# Altium schematic sheet CurrentSensorR3.SchDoc
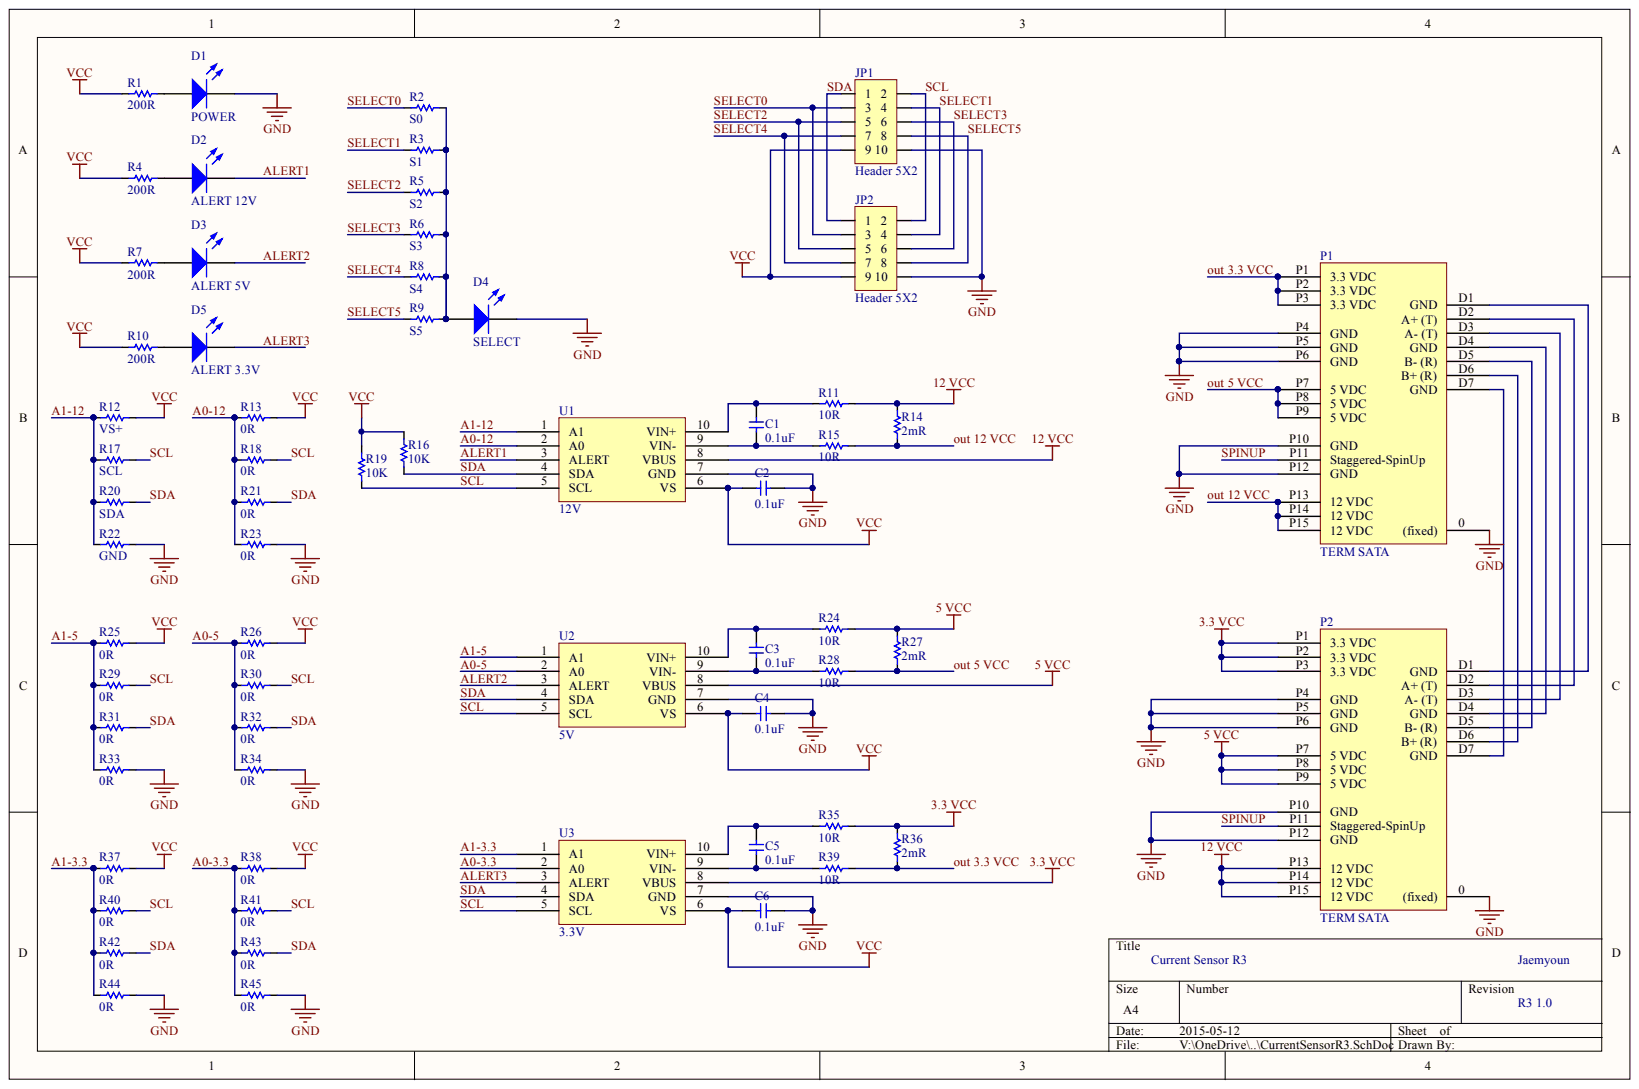
[diagram p0: CurrentSensorR3.SchDoc]

## Parts
C1  0.1uF  [C1608]
C2  0.1uF  [C1608]
C3  0.1uF  [C1608]
C4  0.1uF  [C1608]
C5  0.1uF  [C1608]
C6  0.1uF  [C1608]
D1  POWER  [LED-0603]
D2  ALERT 12V  [LED-0603]
D3  ALERT 5V  [LED-0603]
D4  SELECT  [LED-0603]
D5  ALERT 3.3V  [LED-0603]
JP1  Header 5X2  [TPH03-5A]
JP2  Header 5X2  [TPH03-5A]
P1  TERM SATA  [47018-4001]
P2  TERM SATA  [87703-0001]
R1  200R  [R1608]
R2  S0  [R1608]
R3  S1  [R1608]
R4  200R  [R1608]
R5  S2  [R1608]
R6  S3  [R1608]
R7  200R  [R1608]
R8  S4  [R1608]
R9  S5  [R1608]
R10  200R  [R1608]
R11  10R  [R1608]
R12  VS+  [R1608]
R13  0R  [R1608]
R14  2mR  [R-Shunt]
R15  10R  [R1608]
R16  10K  [R1608]
R17  SCL  [R1608]
R18  0R  [R1608]
R19  10K  [R1608]
R20  SDA  [R1608]
R21  0R  [R1608]
R22  GND  [R1608]
R23  0R  [R1608]
R24  10R  [R1608]
R25  0R  [R1608]
R26  0R  [R1608]
R27  2mR  [R-Shunt]
R28  10R  [R1608]
R29  0R  [R1608]
R30  0R  [R1608]
R31  0R  [R1608]
R32  0R  [R1608]
R33  0R  [R1608]
R34  0R  [R1608]
R35  10R  [R1608]
R36  2mR  [R-Shunt]
R37  0R  [R1608]
R38  0R  [R1608]
R39  10R  [R1608]
R40  0R  [R1608]
R41  0R  [R1608]
R42  0R  [R1608]
R43  0R  [R1608]
R44  0R  [R1608]
R45  0R  [R1608]
U1  12V  [DGS10-M]
U2  5V  [DGS10-M]
U3  3.3V  [DGS10-M]

## Nets
3.3 VCC: P2.P1(3.3_VDC) P2.P2(3.3_VDC) P2.P3(3.3_VDC) R35.2 R36.2 U3.8(VBUS)
5 VCC: P2.P7(5_VDC) P2.P8(5_VDC) P2.P9(5_VDC) R24.2 R27.2 U2.8(VBUS)
12 VCC: P2.P13(12_VDC) P2.P14(12_VDC) P2.P15(12_VDC) R11.2 R14.2 U1.8(VBUS)
GND: C2.2 C4.2 C6.2 D1.K D4.K JP1.10 JP2.10 P1.0((fixed)) P1.P4(GND) P1.P5(GND) P1.P6(GND) P1.P10(GND) P1.P12(GND) P2.0((fixed)) P2.P4(GND) P2.P5(GND) P2.P6(GND) P2.P10(GND) P2.P12(GND) R22.2 R23.2 R33.2 R34.2 R44.2 R45.2 U1.7(GND) U2.7(GND) U3.7(GND)
VCC: C2.1 C4.1 C6.1 JP1.9 JP2.9 R1.1 R4.1 R7.1 R10.1 R12.2 R13.2 R16.2 R19.2 R25.2 R26.2 R37.2 R38.2 U1.6(VS) U2.6(VS) U3.6(VS)
A0-3.3: R38.1 R41.1 R43.1 R45.1 U3.2(A0)
A0-5: R26.1 R30.1 R32.1 R34.1 U2.2(A0)
A0-12: R13.1 R18.1 R21.1 R23.1 U1.2(A0)
A1-3.3: R37.1 R40.1 R42.1 R44.1 U3.1(A1)
A1-5: R25.1 R29.1 R31.1 R33.1 U2.1(A1)
A1-12: R12.1 R17.1 R20.1 R22.1 U1.1(A1)
ALERT1: D2.K U1.3(ALERT)
ALERT2: D3.K U2.3(ALERT)
ALERT3: D5.K U3.3(ALERT)
OUT 3.3 VCC: P1.P1(3.3_VDC) P1.P2(3.3_VDC) P1.P3(3.3_VDC) R36.1 R39.2
OUT 5 VCC: P1.P7(5_VDC) P1.P8(5_VDC) P1.P9(5_VDC) R27.1 R28.2
OUT 12 VCC: P1.P13(12_VDC) P1.P14(12_VDC) P1.P15(12_VDC) R14.1 R15.2
SCL: JP1.2 JP2.2 R17.2 R18.2 R19.1 R29.2 R30.2 R40.2 R41.2 U1.5(SCL) U2.5(SCL) U3.5(SCL)
SDA: JP1.1 JP2.1 R16.1 R20.2 R21.2 R31.2 R32.2 R42.2 R43.2 U1.4(SDA) U2.4(SDA) U3.4(SDA)
SELECT0: JP1.3 JP2.3 R2.1
SELECT1: JP1.4 JP2.4 R3.1
SELECT2: JP1.5 JP2.5 R5.1
SELECT3: JP1.6 JP2.6 R6.1
SELECT4: JP1.7 JP2.7 R8.1
SELECT5: JP1.8 JP2.8 R9.1
SPINUP: P1.P11(Staggered-SpinUp) P2.P11(Staggered-SpinUp)
NetC1_1: C1.1 R15.1 U1.9(VIN-)
NetC1_2: C1.2 R11.1 U1.10(VIN+)
NetC3_1: C3.1 R28.1 U2.9(VIN-)
NetC3_2: C3.2 R24.1 U2.10(VIN+)
NetC5_1: C5.1 R39.1 U3.9(VIN-)
NetC5_2: C5.2 R35.1 U3.10(VIN+)
NetD1_A: D1.A R1.2
NetD2_A: D2.A R4.2
NetD3_A: D3.A R7.2
NetD4_A: D4.A R2.2 R3.2 R5.2 R6.2 R8.2 R9.2
NetD5_A: D5.A R10.2
NetP1_D1: P1.D1(GND) P2.D1(GND)
NetP1_D2: P1.D2(A+_(T)) P2.D2(A+_(T))
NetP1_D3: P1.D3(A-_(T)) P2.D3(A-_(T))
NetP1_D4: P1.D4(GND) P2.D4(GND)
NetP1_D5: P1.D5(B-_(R)) P2.D5(B-_(R))
NetP1_D6: P1.D6(B+_(R)) P2.D6(B+_(R))
NetP1_D7: P1.D7(GND) P2.D7(GND)

## Library data of the parts
C1-C6 = Cap: Capacitor; Value: 100pF; Component Kind: Standard; LatestRevisionDate: 17-Jul-2002; LatestRevisionNote: Re-released for DXP Platform.; Library Name: JLib.IntLib; PackageDescription: Radial Cap, Thru-Hole; 2 Leads; 0.3 in Pin Spacing; PackageReference: RAD-0.3; Published: 8-Jun-2000; Publisher: Altium Limited
D1-D5 = LED: Component Kind: Standard; Description: LED; LatestRevisionDate: 08-Jul-2005; LatestRevisionNote: Mech Layer 1 removed.; Library Name: JLib.IntLib; PackageDescription: LED; 2 Leads; PackageReference: LED-0; Published: 8-Jun-2000; Publisher: Altium Limited
JP1 JP2 = Header 5X2: CONNECTOR; Component Kind: Standard; Library Name: JLib.IntLib
P1 P2 = TERM SATA: serial ATA plug; Vendor: Texas Instruments; Component Kind: Standard; Value: Value
  P1: Library Name: JLib.IntLib
  P2: Library Name: JLib.SchLib
R1-R45 = Res: Resistor; Value: 1K; Component Kind: Standard; LatestRevisionDate: 17-Jul-2002; LatestRevisionNote: Re-released for DXP Platform.; Library Name: JLib.IntLib; PackageDescription: Axial Device, Thru-Hole; 2 Leads; 0.3 in Pin Spacing; PackageReference: AXIAL-0.3; Published: 8-Jun-2000; Publisher: Altium Limited
U1-U3 = INA226: type = DGS_10; Manufacturer: Texas Instruments; Manufacturer_Part_Number: ina226_dgs_10; FAMILY_NAME: CURRENT SHUNT MONITOR; INDUSTRY_STD_PKG_TYPE: MSOP; PACKAGE_DESIGNATOR: DGS; PIN_COUNT: 10; Component Kind: Standard; Datasheet URL: http://www.ti.com/lit/gpn/INA226; GENERIC_PART_NUMBER: INA226; Library Name: JLib.IntLib; RefDes: RefDes; Type: DEV; Value: Value; Vendor: Texas Instruments
